# Altium schematic sheet Infrared Pro_Power.SchDoc("Infrared Pro_Power")
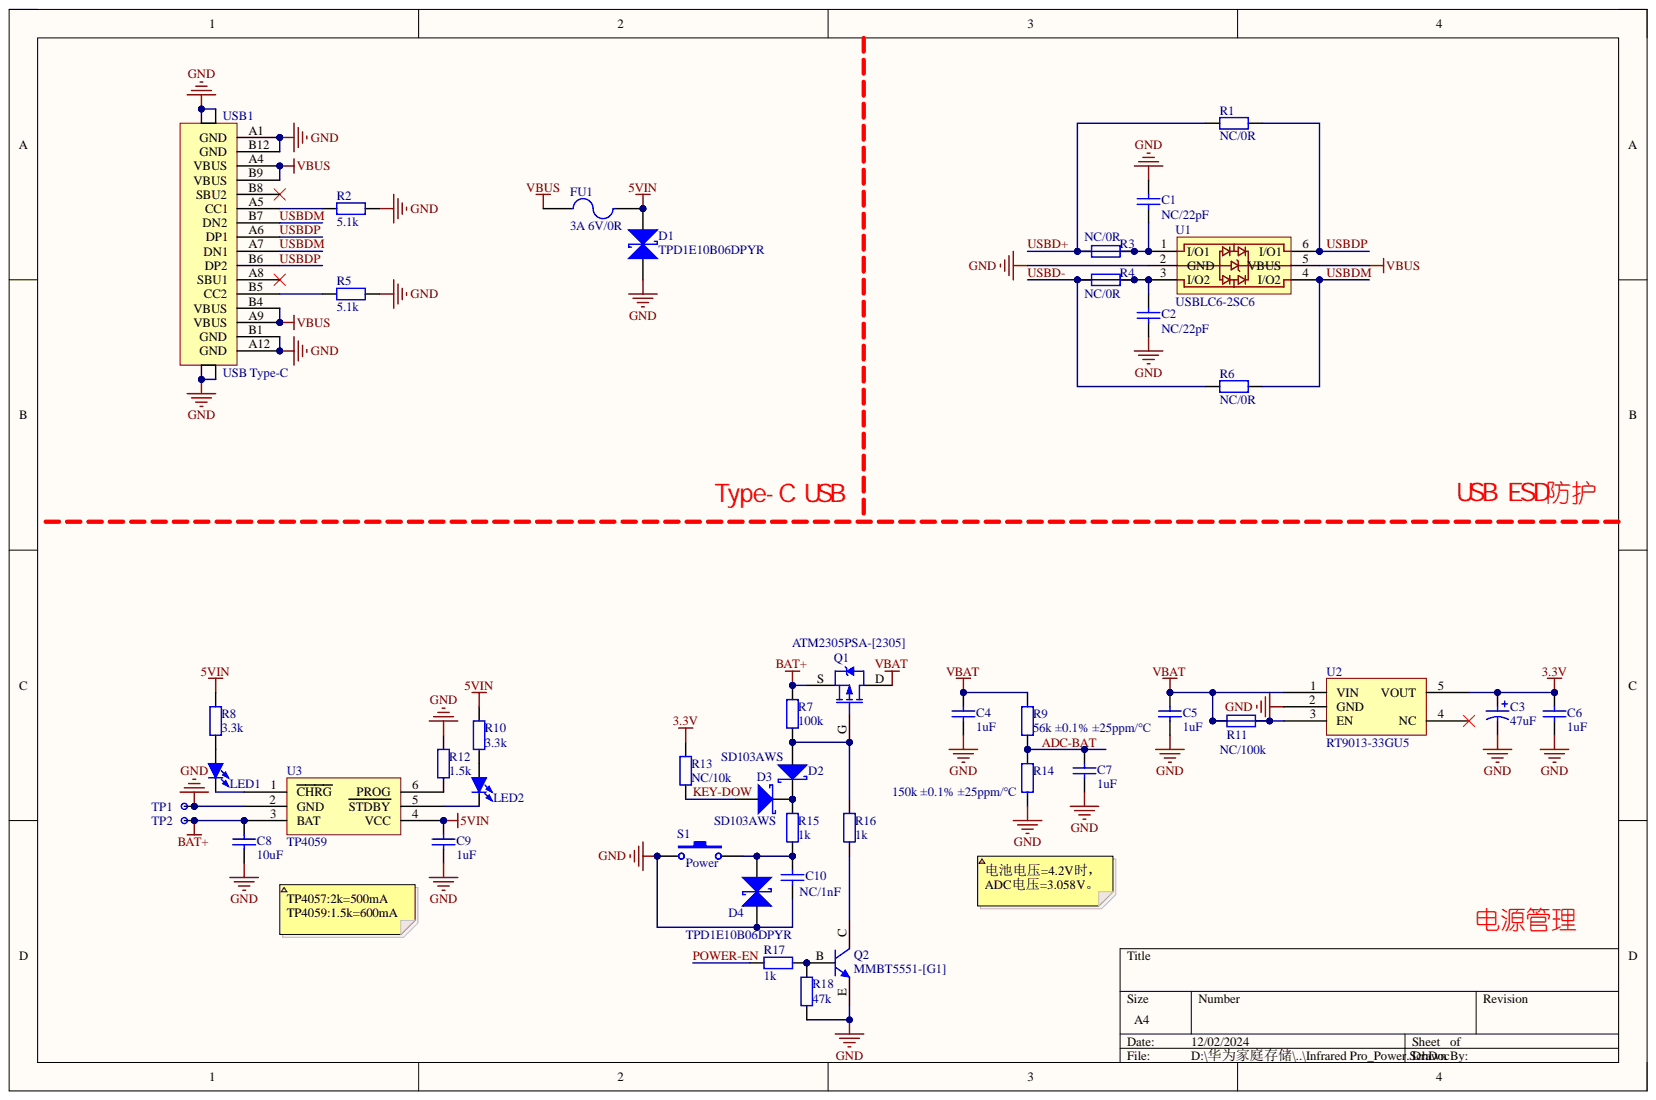
[diagram p0: Infrared Pro_Power.SchDoc]

## Parts
C1  NC/22pF  [C-RAD-0402-L]
C2  NC/22pF  [C-RAD-0402-L]
C3  47uF  [C-CD A(3216-18)]
C4  1uF  [C-RAD-0402-L]
C5  1uF  [C-RAD-0402-L]
C6  1uF  [C-RAD-0402-L]
C7  1uF  [C-RAD-0402-L]
C8  10uF  [C-RAD-0402-L]
C9  1uF  [C-RAD-0402-L]
C10  NC/1nF  [C-RAD-0402-L]
D1  TPD1E10B06DPYR  [X1-SON-2(0.6x1)]
D2  SD103AWS  [SOD-323]
D3  SD103AWS  [SOD-323]
D4  TPD1E10B06DPYR  [X1-SON-2(0.6x1)]
FU1  3A 6V/0R  [Fu 1206]
LED1  0402-Red  [LED 0402-R]  (value not drawn)
LED2  0402-Green  [LED 0402-G]  (value not drawn)
Q1  ATM2305PSA-[2305]  [Q-MOS-P-SOT23]
Q2  MMBT5551-[G1]  [Q-NPN-SOT23]
R1  NC/0R  [RES-0402-L (1/16W)]
R2  5.1k  [RES-0402-L (1/16W)]
R3  NC/0R  [RES-0402-L (1/16W)]
R4  NC/0R  [RES-0402-L (1/16W)]
R5  5.1k  [RES-0402-L (1/16W)]
R6  NC/0R  [RES-0402-L (1/16W)]
R7  100k  [RES-0402-L (1/16W)]
R8  3.3k  [RES-0402-L (1/16W)]
R9  56k ±0.1% ±25ppm/℃  [RES-0402-L (1/16W)]
R10  3.3k  [RES-0402-L (1/16W)]
R11  NC/100k  [RES-0402-L (1/16W)]
R12  1.5k  [RES-0402-L (1/16W)]
R13  NC/10k  [RES-0402-L (1/16W)]
R14  150k ±0.1% ±25ppm/℃  [RES-0402-L (1/16W)]
R15  1k  [RES-0402-L (1/16W)]
R16  1k  [RES-0402-L (1/16W)]
R17  1k  [RES-0402-L (1/16W)]
R18  47k  [RES-0402-L (1/16W)]
S1  Power  [SW-SMD_YD-3410]
TP1  GND  [TP-S-1mm]  (value not drawn)
TP2  BAT+  [TP-C-1mm]
U1  USBLC6-2SC6  [SOT23-6L]
U2  RT9013-33GU5  [SC-70-5]
U3  TP4059  [SOT-23-6]
USB1  USB Type-C  [USB_TYPE-C_F_16P_CB1.6]

## Nets
3.3V: C3.1 C6.2 R13.1 U2.5(VOUT)
5VIN: C9.2 D1.K FU1.2 R8.2 R10.2 U3.4(VCC)
BAT+: C8.2 Q1.S R7.1 TP2.1 U3.3(BAT)
GND: C1.2 C2.1 C3.2 C4.1 C5.1 C6.1 C7.1 C8.1 C9.1 C10.2 D1.A D4.A Q2.E R2.2 R5.2 R12.2 R14.2 R18.2 S1.1 TP1.1 U1.2(GND) U2.2(GND) U3.2(GND) USB1.0 USB1.A1(GND) USB1.A12(GND) USB1.B1(GND) USB1.B12(GND)
VBAT: C4.2 C5.2 Q1.D R9.1 R11.1 R11.2 U2.1(VIN) U2.3(EN)
VBUS: FU1.1 U1.5(VBUS) USB1.A4(VBUS) USB1.A9(VBUS) USB1.B4(VBUS) USB1.B9(VBUS)
ADC-BAT: C7.2 R9.2 R14.1
KEY-DOW: D3.A R13.2
POWER-EN: R17.1
USBD+: C1.1 R1.1 R3.1 R3.2 U1.1(I/O1)
USBD-: C2.2 R4.1 R4.2 R6.1 U1.3(I/O2)
USBDM: R6.2 U1.4(I/O2) USB1.A7(DN1) USB1.B7(DN2)
USBDP: R1.2 U1.6(I/O1) USB1.A6(DP1) USB1.B6(DP2)
NetC10_1: C10.1 D4.K R15.1 S1.2
NetD2_A: D2.A Q1.G R7.2 R16.2
NetD2_K: D2.K D3.K R15.2
NetLED1_1: LED1.1 R8.1
NetLED1_2: LED1.2 U3.1(CHRG)
NetLED2_1: LED2.1 R10.1
NetLED2_2: LED2.2 U3.5(STDBY)
NetQ2_B: Q2.B R17.2 R18.1
NetQ2_C: Q2.C R16.1
NetR2_1: R2.1 USB1.A5(CC1)
NetR5_1: R5.1 USB1.B5(CC2)
NetR12_1: R12.1 U3.6(PROG)

Not connected: U2 4(NC); USB1 A8(SBU1) B8(SBU2)

## Library data of the parts
C1 C2 C4-C10 = Cap: Capacitor; Component Kind: Standard; LatestRevisionDate: 17-Jul-2002; LatestRevisionNote: Re-released for DXP Platform.; Library Name: Master Li.SchLib; PackageDescription: Radial Cap, Thru-Hole; 2 Leads; 0.3 in Pin Spacing; PackageReference: RAD-0.3; Published: 8-Jun-2000; Publisher: Altium Limited
  C1 C2: Value: NC/22pF
  C4-C7 C9: Value: 1uF
  C8: Value: 10uF
  C10: Value: NC/1nF
C3 = Cap(tantalum): tantalum capacitor; Component Kind: Standard; Library Name: Master Li.SchLib; Value: 47uF
D1 D4 = Diode-TVS: 瞬变抑制二极管   TRANSIENT VOLTAGE SUPPRESSOR; Component Kind: Standard; LatestRevisionDate: 29-Mar-2024; LatestRevisionNote: Re-released for DXP Platform.; Library Name: Master Li.SchLib; Published: 29-Mar-2024; Publisher: Altium Limited
D2 D3 = Diode-Schottky: 肖特基二极管   Schottky Barrier Diode; Component Kind: Standard; LatestRevisionDate: 29-Mar-2024; LatestRevisionNote: Re-released for DXP Platform.; Library Name: Master Li.SchLib; Published: 29-Mar-2024; Publisher: Altium Limited
FU1 = Fuse: 保险丝; Component Kind: Standard; LatestRevisionDate: 17-Jul-2002; LatestRevisionNote: Re-released for DXP Platform.; Library Name: Master Li.SchLib; PackageReference: PIN-W2/E2.8; Published: 8-Jun-2000; Publisher: Altium Limited
LED1 LED2 = LED-SMD: 贴片LED; 版权归属: Master Li; BOM名称: 贴片LED; Component Kind: Standard; Library Name: Master Li.SchLib; 学习资源: https://shop237247974.taobao.com/
Q1 = Q-MOS-P: P-Channel Power MOSFET; Note: Pin 2 is electrically connected to pin 4.; Component Kind: Standard; ComponentLink1Description: Manufacturer Link; ComponentLink1URL: http://www.irf.com/; DatasheetVersion: PD-9.814; LatestRevisionDate: 17-Jul-2002; LatestRevisionNote: Re-released for DXP Platform.; Library Name: Master Li.SchLib; PackageDescription: TO, Thru-Hole, Vertical, Heatsink Mounted; 3 In-Line Leads; Pitch 2.54 mm; PackageReference: TO-220AB; PackageVersion: Feb-1997; Published: 8-Jun-2000; Publisher: Altium Limited
Q2 = Q-NPN: NPN Bipolar Transistor; Code_JEDEC: TO-226-AA; Component Kind: Standard; LatestRevisionDate: 17-Jul-2002; LatestRevisionNote: Re-released for DXP Platform.; Library Name: Master Li.SchLib; PackageDescription: TO, Flat Index; 3 In-Line, Axial Leads; Body Dia. 4.8mm; Leads 0.55 x 0.51 mm (max); PackageReference: TO-226-AA; Published: 8-Jun-2000; Publisher: Altium Limited
R1-R18 = Res: Resistor; Component Kind: Standard; LatestRevisionDate: 17-Jul-2002; LatestRevisionNote: Re-released for DXP Platform.; Library Name: Master Li.SchLib; PackageDescription: Axial Device, Thru-Hole; 2 Leads; 0.4 in Pin Spacing; PackageReference: AXIAL-0.4; Published: 8-Jun-2000; Publisher: Altium Limited
  R1 R3 R4 R6: Value: NC/0R
  R2 R5: Value: 5.1k
  R7: Value: 100k
  R8 R10: Value: 3.3k
  R9: Value: 56k ±0.1% ±25ppm/℃
  R11: Value: NC/100k
  R12: Value: 1.5k
  R13: Value: NC/10k
  R14: Value: 150k ±0.1% ±25ppm/℃
  R15-R17: Value: 1k
  R18: Value: 47k
S1 = SW PB - 2P: 2脚轻触按键; Component Kind: Standard; LatestRevisionDate: 17-Jul-2002; LatestRevisionNote: Re-released for DXP Platform.; Library Name: Master Li.SchLib; PackageDescription: Switch; 2 Leads; PackageReference: SPST-2; Published: 24-Mar-1999; Publisher: Altium Limited
TP1 TP2 = CHECK: 测试点; Component Kind: Standard; Library Name: Master Li.SchLib
U1 = USBLC6-2SC6: 静电放电(ESD)保护器件; Component Kind: Standard; Library Name: Master Li.SchLib
U2 = RT9013-33GU5: 低压差250mV@500mA  2.2V to 5.5V ; RT9013-33GU5；丝印：B1=P；RICHTEK(立锜); Component Kind: Standard; Library Name: Master Li.SchLib
U3 = TP4059: 600mA线性锂离子电池充电器 南京拓品微电子; Component Kind: Standard; Library Name: Master Li.SchLib
USB1 = USB_Type-C_F_16P: USB Type-C 16P 母座; Component Kind: Standard; Library Name: Master Li.SchLib; Author: Master Li
